# Altium schematic sheet Tiresias_audio_codec.SchDoc
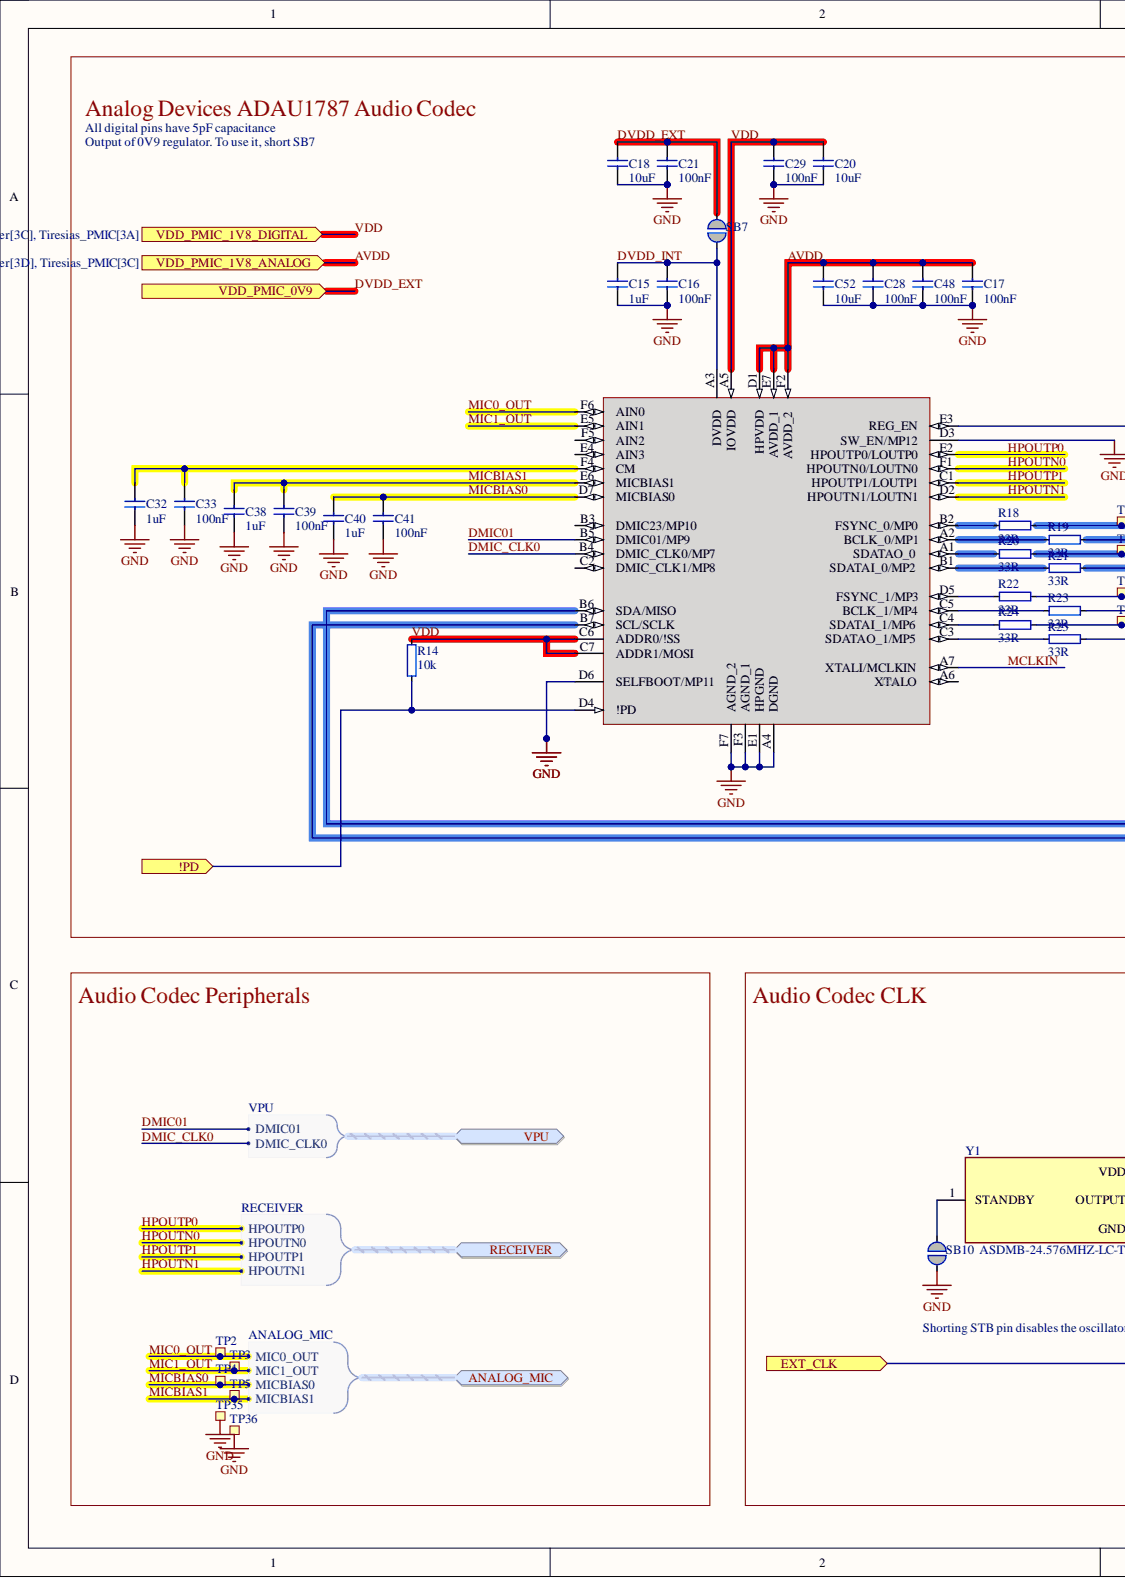
[diagram p0: Tiresias_audio_codec.SchDoc, left]
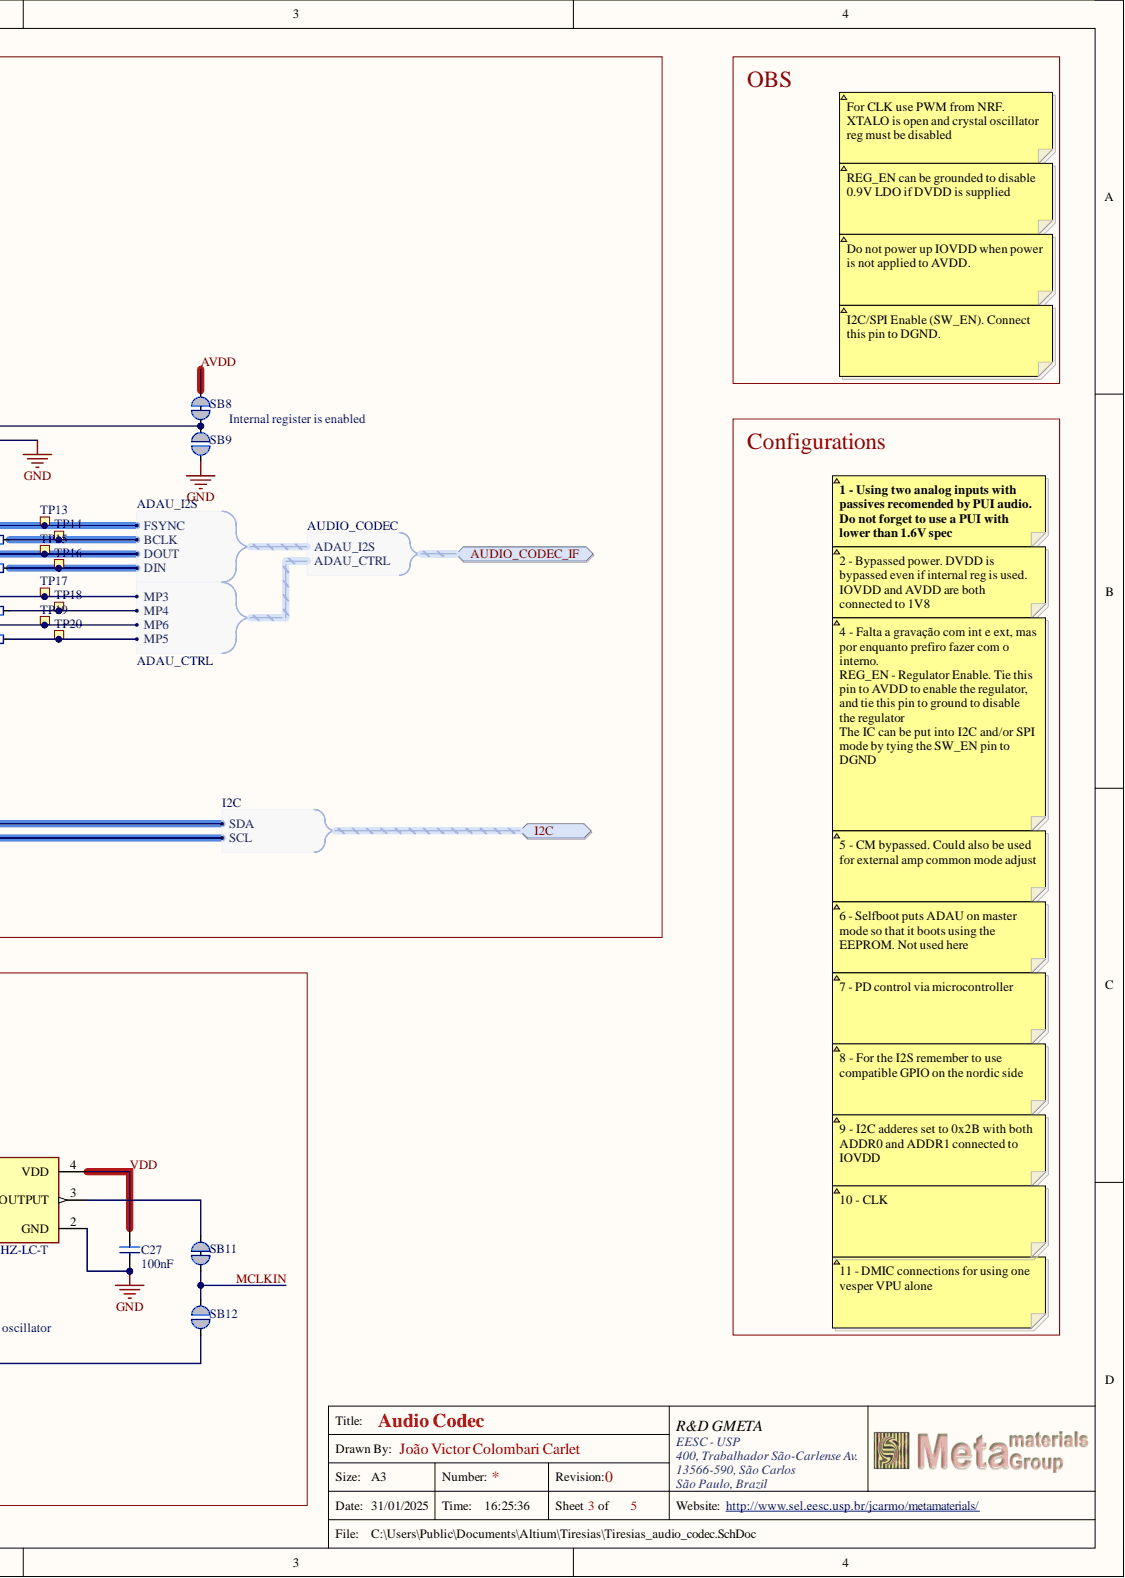
[diagram p1: Tiresias_audio_codec.SchDoc, right]

## Parts
C15  1uF  [CAPC1005X04L]
C16  100nF  [CAPC0603X03L_C]
C17  100nF  [CAPC0603X03L_C]
C18  10uF  [CAPC1005X04L]
C20  10uF  [CAPC1005X04L]
C21  100nF  [CAPC0603X03L_C]
C27  100nF  [CAPC0603X03L_C]
C28  100nF  [CAPC0603X03L_C]
C29  100nF  [CAPC0603X03L_C]
C32  1uF  [CAPC1005X04L]
C33  100nF  [CAPC0603X03L_C]
C38  1uF  [CAPC1005X04L]
C39  100nF  [CAPC0603X03L_C]
C40  1uF  [CAPC1005X04L]
C41  100nF  [CAPC0603X03L_C]
C48  100nF  [CAPC0603X03L_C]
C52  10uF  [CAPC1005X04L]
IC1  General Purpose Audio Codec 4ADC / 2DAC Ch 42-Pin WLCSP T/R   Source:  Datasheet  [BGA42C35P7X6_270X232X53]  (value not drawn)
R14  10k  [RESC0603X03L_C]
R18  33R  [RESC0603X03L_C]
R19  33R  [RESC0603X03L_C]
R20  33R  [RESC0603X03L_C]
R21  33R  [RESC0603X03L_C]
R22  33R  [RESC0603X03L_C]
R23  33R  [RESC0603X03L_C]
R24  33R  [RESC0603X03L_C]
R25  33R  [RESC0603X03L_C]
SB7  Solderbridge  [Solderbridge]  (value not drawn)  (no BOM)
SB8  Solderbridge  [Solderbridge_Shorted]  (value not drawn)
SB9  Solderbridge  [Solderbridge]  (value not drawn)  (no BOM)
SB10  Solderbridge  [Solderbridge]  (value not drawn)  (no BOM)
SB11  Solderbridge  [Solderbridge_Shorted]  (value not drawn)
SB12  Solderbridge  [Solderbridge]  (value not drawn)  (no BOM)
TP2  Ø1.0mm,SMD  [TP_SMD_D1.0mm]  (value not drawn)  (no BOM)
TP3  Ø1.0mm,SMD  [TP_SMD_D1.0mm]  (value not drawn)  (no BOM)
TP4  Ø1.0mm,SMD  [TP_SMD_D1.0mm]  (value not drawn)  (no BOM)
TP5  Ø1.0mm,SMD  [TP_SMD_D1.0mm]  (value not drawn)  (no BOM)
TP13  Ø1.0mm,SMD  [TP_SMD_D1.0mm]  (value not drawn)  (no BOM)
TP14  Ø1.0mm,SMD  [TP_SMD_D1.0mm]  (value not drawn)  (no BOM)
TP15  Ø1.0mm,SMD  [TP_SMD_D1.0mm]  (value not drawn)  (no BOM)
TP16  Ø1.0mm,SMD  [TP_SMD_D1.0mm]  (value not drawn)  (no BOM)
TP17  Ø1.0mm,SMD  [TP_SMD_D1.0mm]  (value not drawn)  (no BOM)
TP18  Ø1.0mm,SMD  [TP_SMD_D1.0mm]  (value not drawn)  (no BOM)
TP19  Ø1.0mm,SMD  [TP_SMD_D1.0mm]  (value not drawn)  (no BOM)
TP20  Ø1.0mm,SMD  [TP_SMD_D1.0mm]  (value not drawn)  (no BOM)
TP35  Ø1.0mm,SMD  [TP_SMD_D1.0mm]  (value not drawn)  (no BOM)
TP36  Ø1.0mm,SMD  [TP_SMD_D1.0mm]  (value not drawn)  (no BOM)
Y1  ASDMB-24.576MHZ-LC-T  [XTAL_ASDMB-24.576MHZ-LC-T]

## Ports
!PD, ANALOG_MIC, ANALOG_MIC.MIC0_OUT, ANALOG_MIC.MIC1_OUT, ANALOG_MIC.MICBIAS0, ANALOG_MIC.MICBIAS1, AUDIO_CODEC_IF, AUDIO_CODEC_IF.ADAU_CTRL, AUDIO_CODEC_IF.ADAU_CTRL.MP3, AUDIO_CODEC_IF.ADAU_CTRL.MP4, AUDIO_CODEC_IF.ADAU_CTRL.MP5, AUDIO_CODEC_IF.ADAU_CTRL.MP6, AUDIO_CODEC_IF.ADAU_I2S, AUDIO_CODEC_IF.ADAU_I2S.BCLK, AUDIO_CODEC_IF.ADAU_I2S.DIN, AUDIO_CODEC_IF.ADAU_I2S.DOUT, AUDIO_CODEC_IF.ADAU_I2S.FSYNC, EXT_CLK, I2C, I2C.SCL, I2C.SDA, RECEIVER, RECEIVER.HPOUTN0, RECEIVER.HPOUTN1, RECEIVER.HPOUTP0, RECEIVER.HPOUTP1, VDD_PMIC_0V9, VDD_PMIC_1V8_ANALOG, VDD_PMIC_1V8_DIGITAL, VPU, VPU.DMIC01, VPU.DMIC_CLK0

## Nets
GND: C15.1 C16.2 C17.2 C18.1 C20.1 C21.2 C27.2 C28.2 C29.2 C32.1 C33.2 C38.1 C39.2 C40.1 C41.2 C48.2 C52.1 IC1.A4(DGND) IC1.D3(SW_EN/MP12) IC1.D6(SELFBOOT/MP11) IC1.E1(HPGND) IC1.F3(AGND_1) IC1.F7(AGND_2) SB9.2 SB10.2 TP35.1 TP36.1 Y1.2(GND)
!PD: IC1.D4(!PD) R14.1 ; port !PD
AVDD: C17.1 C28.1 C48.1 C52.2 IC1.D1(HPVDD) IC1.E7(AVDD_1) IC1.F2(AVDD_2) SB8.1 ; port VDD_PMIC_1V8_ANALOG
DMIC01: IC1.B5(DMIC01/MP9) ; port VPU
DMIC_CLK0: IC1.B4(DMIC_CLK0/MP7) ; port VPU
DVDD_EXT: C18.2 C21.1 SB7.1 ; port VDD_PMIC_0V9
DVDD_INT: C15.2 C16.1 IC1.A3(DVDD) SB7.2
EXT_CLK: SB12.2 ; port EXT_CLK
HPOUTN0: IC1.F1(HPOUTN0/LOUTN0) ; port RECEIVER
HPOUTN1: IC1.D2(HPOUTN1/LOUTN1) ; port RECEIVER
HPOUTP0: IC1.E2(HPOUTP0/LOUTP0) ; port RECEIVER
HPOUTP1: IC1.C1(HPOUTP1/LOUTP1) ; port RECEIVER
MCLKIN: IC1.A7(XTALI/MCLKIN) SB11.2 SB12.1
MIC0_OUT: IC1.F6(AIN0) TP2.1 ; port ANALOG_MIC
MIC1_OUT: IC1.E5(AIN1) TP3.1 ; port ANALOG_MIC
MICBIAS0: C40.2 C41.1 IC1.D7(MICBIAS0) TP4.1 ; port ANALOG_MIC
MICBIAS1: C38.2 C39.1 IC1.E6(MICBIAS1) TP5.1 ; port ANALOG_MIC
VDD: C20.2 C27.1 C29.1 IC1.A5(IOVDD) IC1.C6(ADDR0/!SS) IC1.C7(ADDR1/MOSI) R14.2 Y1.4(VDD) ; port VDD_PMIC_1V8_DIGITAL
NetC32_2: C32.2 C33.1 IC1.F4(CM)
NetIC1_A1: IC1.A1(SDATAO_0) R20.1
NetIC1_A2: IC1.A2(BCLK_0/MP1) R19.1
NetIC1_A6: IC1.A6(XTALO)
NetIC1_B1: IC1.B1(SDATAI_0/MP2) R21.1
NetIC1_B2: IC1.B2(FSYNC_0/MP0) R18.1
NetIC1_B3: IC1.B3(DMIC23/MP10)
NetIC1_B6: IC1.B6(SDA/MISO) ; port I2C
NetIC1_B7: IC1.B7(SCL/SCLK) ; port I2C
NetIC1_C2: IC1.C2(DMIC_CLK1/MP8)
NetIC1_C3: IC1.C3(SDATAO_1/MP5) R25.1
NetIC1_C4: IC1.C4(SDATAI_1/MP6) R24.1
NetIC1_C5: IC1.C5(BCLK_1/MP4) R23.1
NetIC1_D5: IC1.D5(FSYNC_1/MP3) R22.1
NetIC1_E3: IC1.E3(REG_EN) SB8.2 SB9.1
NetIC1_E4: IC1.E4(AIN3)
NetIC1_F5: IC1.F5(AIN2)
NetR18_2: R18.2 TP13.1 ; port AUDIO_CODEC_IF
NetR19_2: R19.2 TP14.1 ; port AUDIO_CODEC_IF
NetR20_2: R20.2 TP15.1 ; port AUDIO_CODEC_IF
NetR21_2: R21.2 TP16.1 ; port AUDIO_CODEC_IF
NetR22_2: R22.2 TP17.1 ; port AUDIO_CODEC_IF
NetR23_2: R23.2 TP18.1 ; port AUDIO_CODEC_IF
NetR24_2: R24.2 TP19.1 ; port AUDIO_CODEC_IF
NetR25_2: R25.2 TP20.1 ; port AUDIO_CODEC_IF
NetSB10_1: SB10.1 Y1.1(STANDBY)
NetSB11_1: SB11.1 Y1.3(OUTPUT)

## Library data of the parts
C15 C32 C38 C40 = CAP_0402_1uF: Capacitor, X5R, ±5%; Part Number: C0402-1µ0; Class: SMT; Component Kind: Standard; LatestRevisionDate: 2024/02/20; Package: 0402; Pin Count: 2; Value: 1uF; Library Name: Tiresias_passive.SchLib
C16 C17 C21 C27-C29 C33 C39 C41 C48 = CAP_0201_100nF: Capacitor, NP0, ±2%; Part Number: C0201-100n; Class: SMT; Component Kind: Standard; LatestRevisionDate: 2024/02/20; Package: 0201; Pin Count: 2; Value: 100nF; Library Name: Tiresias_passive.SchLib
C18 C20 C52 = CAP_0402_10uF: Capacitor, X5R, ±5%; Part Number: C0402-1µ0; Class: SMT; Component Kind: Standard; LatestRevisionDate: 2024/02/20; Package: 0402; Pin Count: 2; Value: 10uF; Library Name: Tiresias_passive.SchLib
IC1 = ADAU1787BCBZRL: Manufacturer_Name: Analog Devices; GateName_1: G$1; Component Kind: Standard; DeviceSetName: ADAU1787BCBZRL; Height: 0.53mm; LibraryName: ADAU1787BCBZRL; Manufacturer_Part_Number: ADAU1787BCBZRL; Mouser_Part_Number: 584-ADAU1787BCBZRL; Mouser_Price-Stock: https://www.mouser.co.uk/ProductDetail/Analog-Devices/ADAU1787BCBZRL?qs=Zz7%252BYVVL6bEB%252B919c1w04A%3D%3D; Package: BGA42C35P7X6_270X232X53; SymbolName_1: ADAU1787BCBZRL; Library Name: Tiresias_logic.SchLib
R14 = RES_0201_10K: Resistor, ±1%, 0.05W; Manufacturer: N.A.; Part Number: R0201-100k; Class: SMT; Component Kind: Standard; ComponentLink1Description: Datasheet; ComponentLink1URL: N.A.; ComponentLink2Description: Supplier link; ComponentLink2URL: N.A.; DigiKey link: 00000001419; JLCpcb link: Chip Res 1%; Latest Revision Date: 2024-04-23; Mouser link: N.A.; Package: 0201; Pin Count: 2; Value: 10k; Author: rsk; Library Name: Tiresias_passive.SchLib
R18-R25 = RES_0201_33R: Resistor, ±1%, 0.05W; Part Number: R0201-220; Part number IPS: 00000000863; Artikkelnr Noca: N.A.; Note: Chip Res 1%; Pad Count: 2; Component Class: Resistors; Component Kind: Standard; ComponentLink1Description: Datasheet; ComponentLink1URL: N.A.; ComponentLink2Description: Supplier link; ComponentLink2URL: N.A.; Footprint Doc: 0201; HelpURL: N.A.; LatestRevisionDate: 2014-11-13; Manufacturer: N.A.; Manufacturer Part Number: N.A.; Part number Norautron: N.A.; PartId: 100100032; Published: 2014-11-13; Supplier 1: N.A.; Supplier 2: N.A.; Supplier Part Number 1: N.A.; Supplier Part Number 2: N.A.; Value: 33R; Author: rsk; Library Name: Tiresias_passive.SchLib
SB7 SB9 SB10 SB12 = Solderbridge: Artikkelnr Noca: N.A.; Pad Count: 2; Component Class: Connectors; ComponentLink1Description: Datasheet; ComponentLink1URL: N.A.; ComponentLink2Description: Supplier link; ComponentLink2URL: N.A.; Description: Solderbridge; Footprint Doc: Solderbridge; HelpURL: N.A.; LatestRevisionDate: 2009-12-14; Manufacturer: N.A.; Manufacturer Part Number: N.A.; Note: N.A.; Part Number: Solderbridge; Part number IPS: N.A.; Part number Norautron: N.A.; PartId: 100500458; Published: 2009-12-14; Supplier 1: N.A.; Supplier 2: N.A.; Supplier Part Number 1: N.A.; Supplier Part Number 2: N.A.; Value: Solderbridge; Author: rsk; Library Name: Tiresias_mechanical.SchLib
SB8 SB11 = Solderbridge Shorted: Shorted Solderbridge; Artikkelnr Noca: N.A.; Pad Count: 2; Component Class: Connectors; Component Kind: Net Tie; ComponentLink1Description: Datasheet; ComponentLink1URL: N.A.; ComponentLink2Description: Supplier link; ComponentLink2URL: N.A.; Footprint Doc: Solderbridge_Shorted; HelpURL: N.A.; LatestRevisionDate: 2012-08-07; Manufacturer: N.A.; Manufacturer Part Number: N.A.; Note: N.A.; Part Number: Solderbridge Shorted; Part number IPS: N.A.; Part number Norautron: N.A.; PartId: 100500459; Published: 2012-08-07; Supplier 1: N.A.; Supplier 2: N.A.; Supplier Part Number 1: N.A.; Supplier Part Number 2: N.A.; Value: Solderbridge; Author: rsk; Library Name: Tiresias_mechanical.SchLib
TP2-TP5 TP13-TP20 TP35 TP36 = TP_SMD_Ø1.0MM: 1.0mm circular SMD testpad; Manufacturer: Nordic Semiconductor; Artikkelnr Noca: N.A.; Pad Count: 1; Component Class: Connectors; ComponentLink1Description: Datasheet; ComponentLink1URL: N.A.; ComponentLink2Description: Supplier link; ComponentLink2URL: N.A.; Footprint Doc: TP_SMD_Ø1.0mm; HelpURL: N.A.; LatestRevisionDate: 2021-03-05; Manufacturer Part Number: N.A.; Note: N.A.; Part Number: TP_SMD_Ø1.0MM; Part number IPS: N.A.; Part number Norautron: N.A.; PartId: 100500475; Published: 2013-06-11; Supplier 1: N.A.; Supplier 2: N.A.; Supplier Part Number 1: N.A.; Supplier Part Number 2: N.A.; Value: Ø1.0mm,SMD; Author: rsk; Library Name: Tiresias_mechanical.SchLib
Y1 = ASDMB-24.576MHZ-LC-T: Availability: Unavailable; Component Kind: Standard; MP: ASDMB-24.576MHZ-LC-T; Package: None; Price: None; Library Name: Tiresias_active.SchLib
